# Altium schematic sheet Power.SchDoc
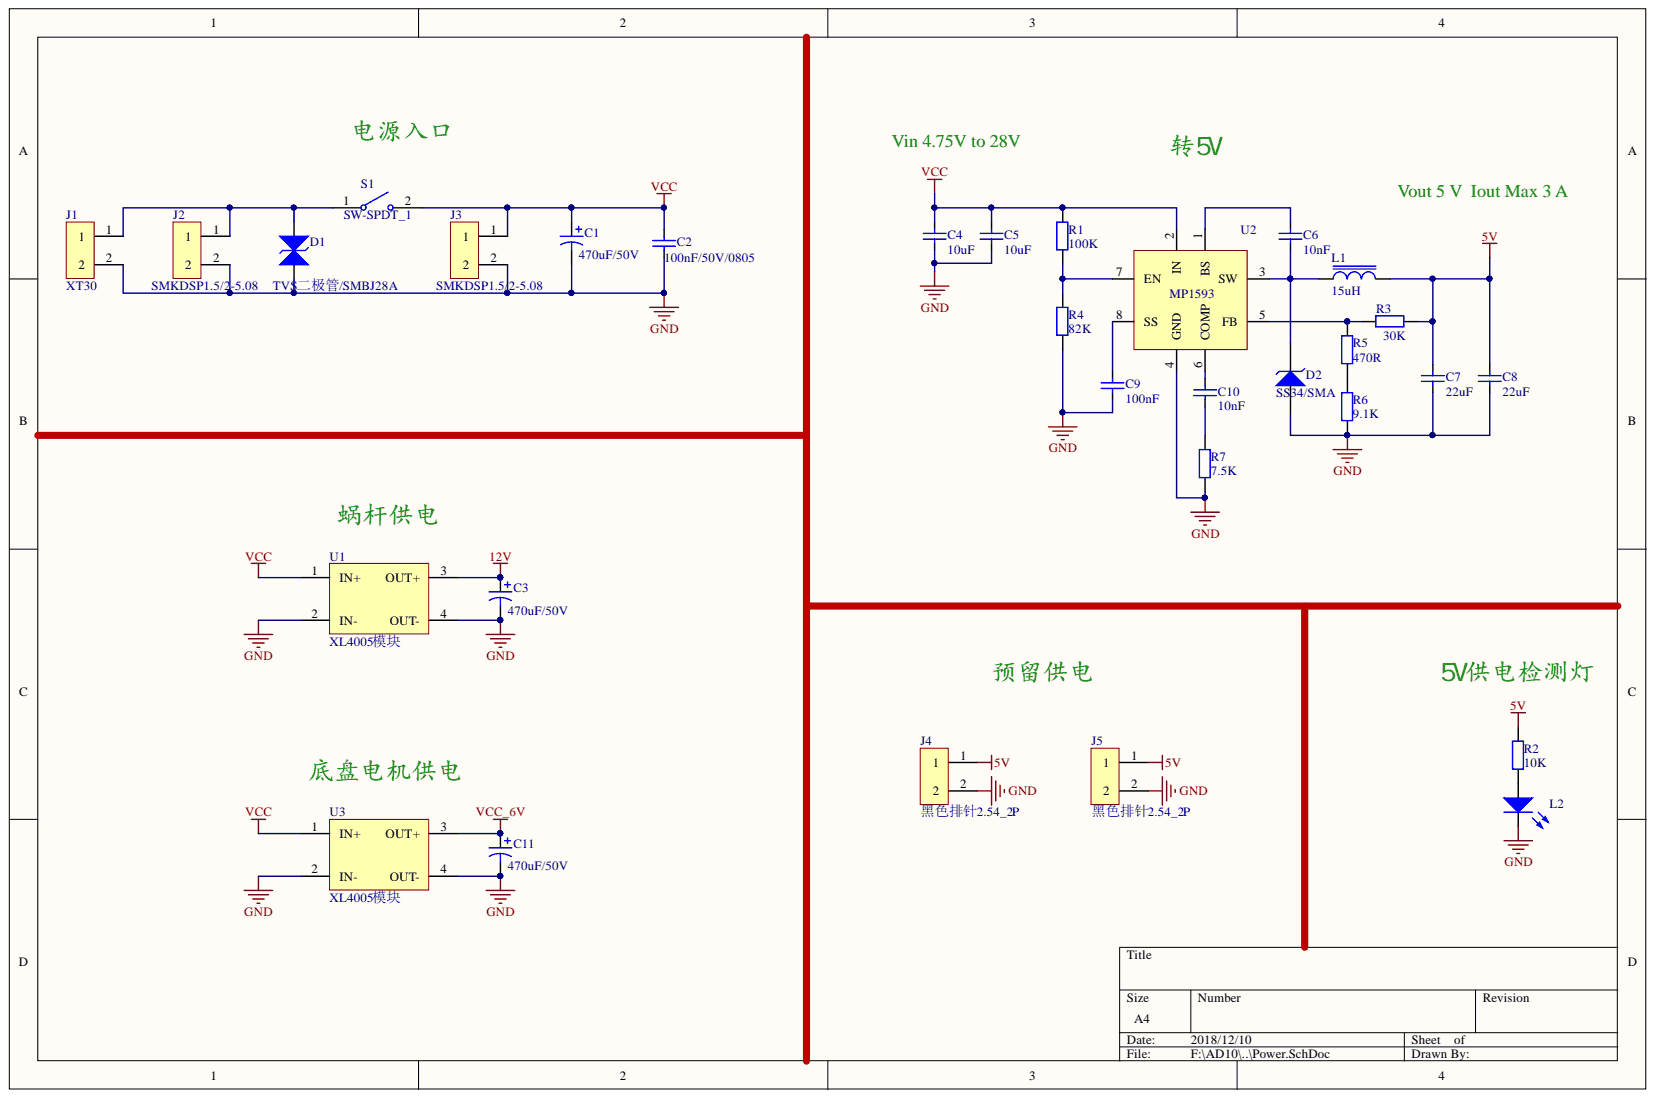
[diagram p0: Power.SchDoc]

## Parts
C1  470uF/50V  [C-RB-S10]
C2  100nF/50V/0805  [LC-0805_C]
C3  470uF/50V  [C-RB-S10]
C4  10uF  [LC-1210_C]
C5  10uF  [LC-1210_C]
C6  10nF  [LC-0603_C]
C7  22uF  [LC-0805_C]
C8  22uF  [LC-0805_C]
C9  100nF  [LC-0603_C]
C10  10nF  [LC-0603_C]
C11  470uF/50V  [C-RB-S10]
D1  TVS二极管/SMBJ28A  [LC-SMB(DO-214AA)_S1]
D2  SS34/SMA  [LC-SMA(DO-214AC)_S1]
J1  XT30  [XT30母头]
J2  SMKDSP1.5/2-5.08  [电源接线口5.08]
J3  SMKDSP1.5/2-5.08  [电源接线口5.08]
J4  黑色排针2.54_2P  [HDR2X1_A]
J5  黑色排针2.54_2P  [HDR2X1_A]
L1  15uH  [L-SMD-7]
L2  LED_0603_RED  [LC-0603_LED_S1]  (value not drawn)
R1  100K  [LC-0603_R]
R2  10K  [LC-0603_R]
R3  30K  [LC-0603_R]
R4  82K  [LC-0603_R]
R5  470R  [LC-0603_R]
R6  9.1K  [LC-0603_R]
R7  7.5K  [LC-0603_R]
S1  SW-SPDT_1  [2脚2档不带灯]
U1  XL4005模块  [XL4005模块]
U2  MP1593  [MP1593]
U3  XL4005模块  [XL4005模块]

## Nets
5V: C7.2 C8.2 J4.1 J5.1 L1.2 R2.1 R3.1
12V: C3.1 U1.3(OUT+)
GND: C1.2 C2.1 C3.2 C4.1 C5.1 C7.1 C8.1 C9.1 C11.2 D1.1 D2.1 J1.2 J2.2 J3.2 J4.2 J5.2 L2.2 R4.1 R6.1 R7.1 U1.2(IN-) U1.4(OUT-) U2.4(GND) U3.2(IN-) U3.4(OUT-)
VCC: C1.1 C2.2 C4.2 C5.2 J3.1 R1.2 S1.2 U1.1(IN+) U2.2(IN) U3.1(IN+)
VCC_6V: C11.1 U3.3(OUT+)
NetC6_1: C6.1 D2.2 L1.1 U2.3(SW)
NetC6_2: C6.2 U2.1(BS)
NetC9_2: C9.2 U2.8(SS)
NetC10_1: C10.1 R7.2
NetC10_2: C10.2 U2.6(COMP)
NetD1_2: D1.2 J1.1 J2.1 S1.1
NetL2_1: L2.1 R2.2
NetR1_1: R1.1 R4.2 U2.7(EN)
NetR3_2: R3.2 R5.2 U2.5(FB)
NetR5_1: R5.1 R6.2

## Library data of the parts
C1 C3 C11 = Cap-电解电容: Component Kind: Standard; Library Name: 工作原理库.SchLib
C2 C7 C8 = Cap_0805: Component Kind: Standard; Description: Cap_0805; Library Name: 工作原理库.SchLib
C4 C5 = Cap_1210: Component Kind: Standard; Description: Cap_1210; Library Name: 工作原理库.SchLib
C6 C9 C10 = Cap_0603: Component Kind: Standard; Description: Cap_0603; Value: Cap_0603; Library Name: 工作原理库.SchLib
D1 = 双向TVS管: Component Kind: Standard; Library Name: 工作原理库.SchLib
D2 = SMA肖特基二极管: Component Kind: Standard; Library Name: 工作原理库.SchLib
J1-J5 = CON2: Component Kind: Standard; Library Name: 工作原理库.SchLib
L1 = Inductor_1: 电感; Code_IEC: 1005; Code_JEITA: 0402; Component Kind: Standard; LatestRevisionDate: 17-Jul-2002; LatestRevisionNote: Re-released for DXP Platform.; PackageReference: 0402-A; Published: 8-Jun-2000; Value: Inductor; Library Name: 工作原理库.SchLib; Publisher: Altium Limited
L2 = LED_0603_RED: LED; Component Kind: Standard; Library Name: 工作原理库.SchLib
R1-R7 = Res_0603: Component Kind: Standard; Description: Res_0603; Value: Res_0603; Library Name: 工作原理库.SchLib
S1 = SW-SPDT_1: 开关; Component Kind: Standard; Library Name: 我的原理图库.SchLib
U1 U3 = XL4005 可调降压电源: Component Kind: Standard; Library Name: 工作原理库.SchLib
U2 = MP1593: Component Kind: Standard; Library Name: RM_步兵主控板(梯形板)原理图库.SchLib
